# Altium schematic sheet rf.SchDoc(rf)
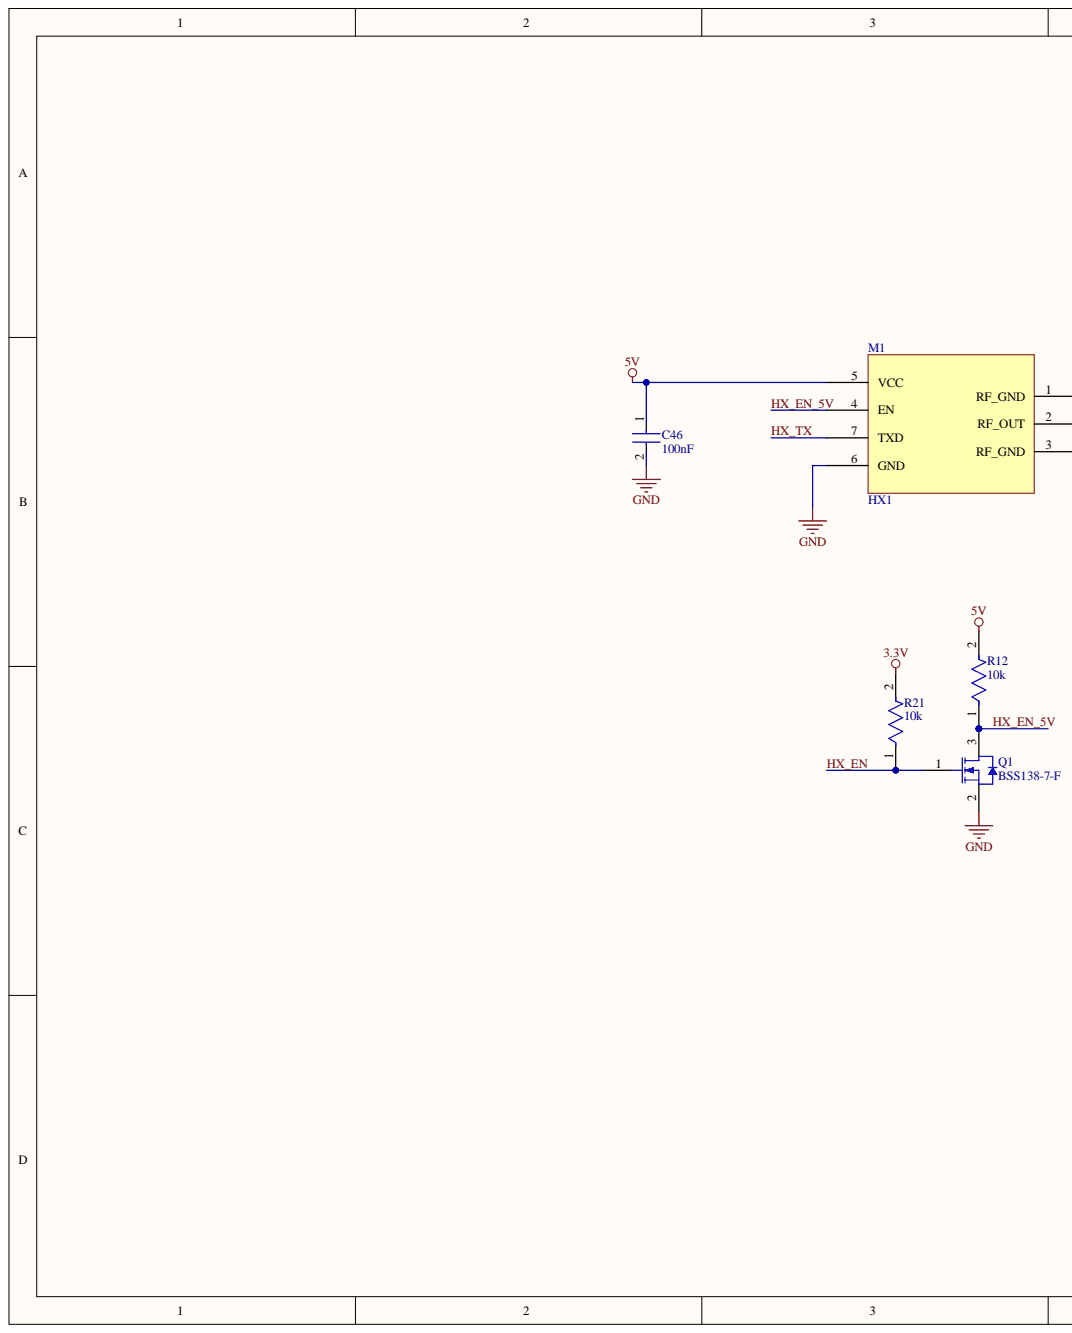
[diagram p0: rf.SchDoc, left]
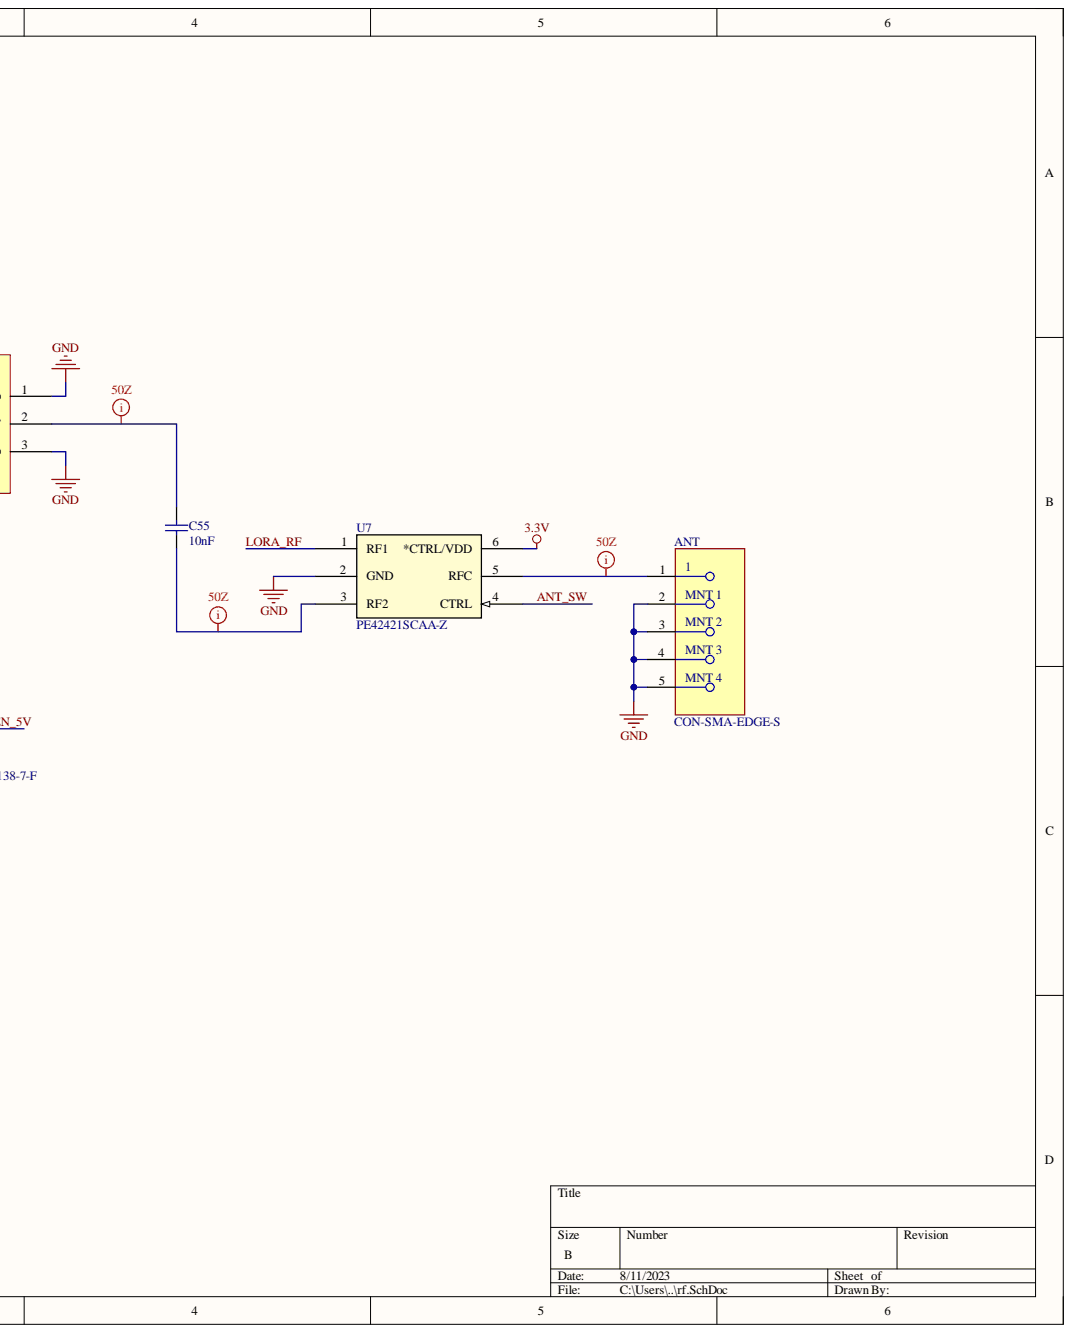
[diagram p1: rf.SchDoc, right]

## Parts
ANT  CON-SMA-EDGE-S  [FP-CON-SMA-EDGE-S-MFG]
C46  100nF  [FP-C0603C-CF-MFG]
C55  10nF  [CAPC2013X88X50ML20T25]
M1  HX1  [PCBComponent_1]
Q1  BSS138-7-F  [DIOD-SOT23-3_V]
R12  10k  [FP-RT0603-MFG]
R21  10k  [FP-RT0603-MFG]
U7  PE42421SCAA-Z  [SC-70-6_PSM]

## Nets
3.3V: R21.2 U7.6(*CTRL/VDD)
5V: C46.1 M1.5(VCC) R12.2
GND: ANT.2 ANT.3 ANT.4 ANT.5 C46.2 M1.1(RF_GND) M1.3(RF_GND) M1.6(GND) Q1.2 U7.2(GND)
ANT_SW: U7.4(CTRL)
HX_EN: Q1.1 R21.1
HX_EN_5V: M1.4(EN) Q1.3 R12.1
HX_TX: M1.7(TXD)
LORA_RF: U7.1(RF1)
NetANT_1: ANT.1 U7.5(RFC)
NetC55_1: C55.1 U7.3(RF2)
NetC55_2: C55.2 M1.2(RF_OUT)

## Library data of the parts
ANT = CMP-204357-000001-1: CONN SMA JACK STR EDGE MNT; Manufacturer: RF Solutions Ltd.; Conn Orientation: Right Angle; Frequency: 18GHz; Impedance: 50Ω; Is Female: yes; Number Of Contacts: 1; Shielding: true; Automotive: No; Category: Conn; Component Kind: Standard; ComponentLink2Description: Datasheet; ComponentLink2URL: https://www.rfsolutions.co.uk/downloads/1498648656DS-CON-EDGE-SMA-4.pdf; Contact Material: Gold; Device Class L1: Connectors; Device Class L2: RF / Coaxial Connectors; Device Class L3: unset; Digikey Description: CONN SMA JACK STR EDGE MNT; Footprint Url: https://forum.upverter.com/uploads/default/original/3X/b/e/be75b907af57498775929d6d9099689c472e0307.pdf; Height: 6.35mm; Lead Free: Yes; Library Name: Altium Content Vault; Manufacturer Part Number: CON-SMA-EDGE-S; Octopart: https://octopart.com/con-sma-edge-s-rf+solutions-70967450; Package: CONN_JACK; RoHS: TRUE
C46 = CMP-2006-03061-2: CAP CER 0.1UF 50V X7R 0603; Manufacturer: KEMET; Manufacturer Part Number: C0603C104K5RACTU; Automotive Grade: Grade 1; Capacitance: 0.10 μF; Material: Ceramic; Temperature Coefficient: 30ppm/°C; Tolerance: 0.1; Value: 0.10 μF; Automotive: Yes; Category: Cap; Component Kind: Standard; ComponentLink2Description: Datasheet; ComponentLink2URL: https://api.kemet.com/component-edge/download/datasheet/C0603C100J5GACAUTO.pdf; Device Class L1: Passive Components; Device Class L2: Capacitors; Device Class L3: Ceramic Capacitors; Digikey Description: CAP CER 0.1UF 50V X7R 0603; Height: 0.87mm; Lead Free: Yes; Library Name: Altium Content Vault; Octopart: https://octopart.com/c0603c104k5ractu-kemet-139244; Package: C0603C-CF; RoHS: TRUE; Temperature: -55°C to +125°C; Temperature Characteristic: X7R; Temperature Range High: +125°C; Temperature Range Low: -55°C; Voltage Rating: 50 V
C55 = CMP-1036-03840-2: Manufacturer: Kemet; Part Number: C0805C103J5GACTU; Component Kind: Standard; Library Name: Altium Content Vault
M1 = CMP-054-000000-3: Component Kind: Standard; Design Item ID: HX1; Source: HX1.SchLib; Library Name: Purdue Space Program - High Altitude
Q1 = CMP-2000-04930-1: N-Channel Enhancement Mode Mosfet, 50 V, 0.2 A, -55 to 150 degC, 3-Pin SOT23, RoHS, Tape and Reel; Manufacturer: Diodes Inc; Brand: DiodesIncorporated; Configuration: Single; Id - Continuous Drain Current: 200mA; Number of Channels: 1Channel; Pd - Power Dissipation: 300mW; Rds On - Drain-Source Resistance: 3.5Ohms; Transistor Type: 1N-Channel; Typical Turn-Off Delay Time: 20ns; Unit Weight: 0.000282oz; Vds - Drain-Source Breakdown Voltage: 50V; Vgs - Gate-Source Voltage: 20V; Channel Mode: Enhancement; Component Kind: Standard; Datasheet URL: https://datasheet.ciiva.com/8115/ds30144-8115344.pdf; Datasheet Version: Rev. 20-2, 11/2013; Factory Pack Quantity: 3000; Height: 1mm; Length: 2.9mm; Library Name: Altium Content Vault; Manufacturer URL: http://www.diodes.com/; Maximum Operating Temperature: +150C; Minimum Operating Temperature: -55C; Mounting Style: SMD/SMT; Package / Case: SOT-23-3; Package Description: 3-Pin Small Outline Transistor, Body 2.9 x 1.3 mm, Pitch 0.96 mm; Package Reference: SOT23-3; Packaging: Reel; Product: MOSFETSmallSignal; Product Category: MOSFET; RoHS: True; Series: BSS138; Technology: Si; Transistor Polarity: N-Channel; Typical Turn-On Delay Time: 20ns; Width: 1.3mm
R12 R21 = CMP-2000-04517-2: RES SMD 10K OHM 0.1% 1/10W 0603; Manufacturer: Yageo; Manufacturer Part Number: RT0603BRE0710KL; Power Rating: 0.1W; Resistance: 10KΩ; Temperature Coefficient: 50 ppm/°C; Tolerance: 0.001; Value: 10KΩ; Voltage Rating: 75V; Automotive: No; Category: Res; Component Kind: Standard; ComponentLink2Description: Datasheet; ComponentLink2URL: http://www.yageo.com/documents/recent/PYu-RT_1-to-0.01_RoHS_L_9.pdf; Composition: Thin Film; Device Class L1: Passive Components; Device Class L2: Resistors; Device Class L3: Chip SMD Resistors; Digikey Description: RES SMD 10K OHM 0.1% 1/10W 0603; Footprint Url: http://www.yageo.com/exep/pages/download/literatures/PYu-R_Mount_10.pdf; Height: 0.55mm; Ipc Land Pattern Name: RESC16080X45; Lead Free: Yes; Library Name: Altium Content Vault; Mouser Description: Res Thin Film 0603 10K Ohm 0.1% 1/10W ±50ppm/°C Molded SMD SMD Paper T/R; Octopart: https://octopart.com/rt0603bre0710kl-yageo-1273959; Package: RT0603; RoHS: TRUE; Series: RT; Temperature: -55°C to +155°C; Temperature Range High: +155°C; Temperature Range Low: -55°C
U7 = CMP-059-000000-1: No Description Available; Mfr_Name: pSemi; Component Kind: Standard; Design Item ID: PE42421SCAA-Z; RefDes: RefDes; Source: PE42421SCAA-Z.SchLib; Type: RF Switches; Library Name: Purdue Space Program - High Altitude
